# Altium schematic sheet TopSheet.SchDoc(TopSheet)
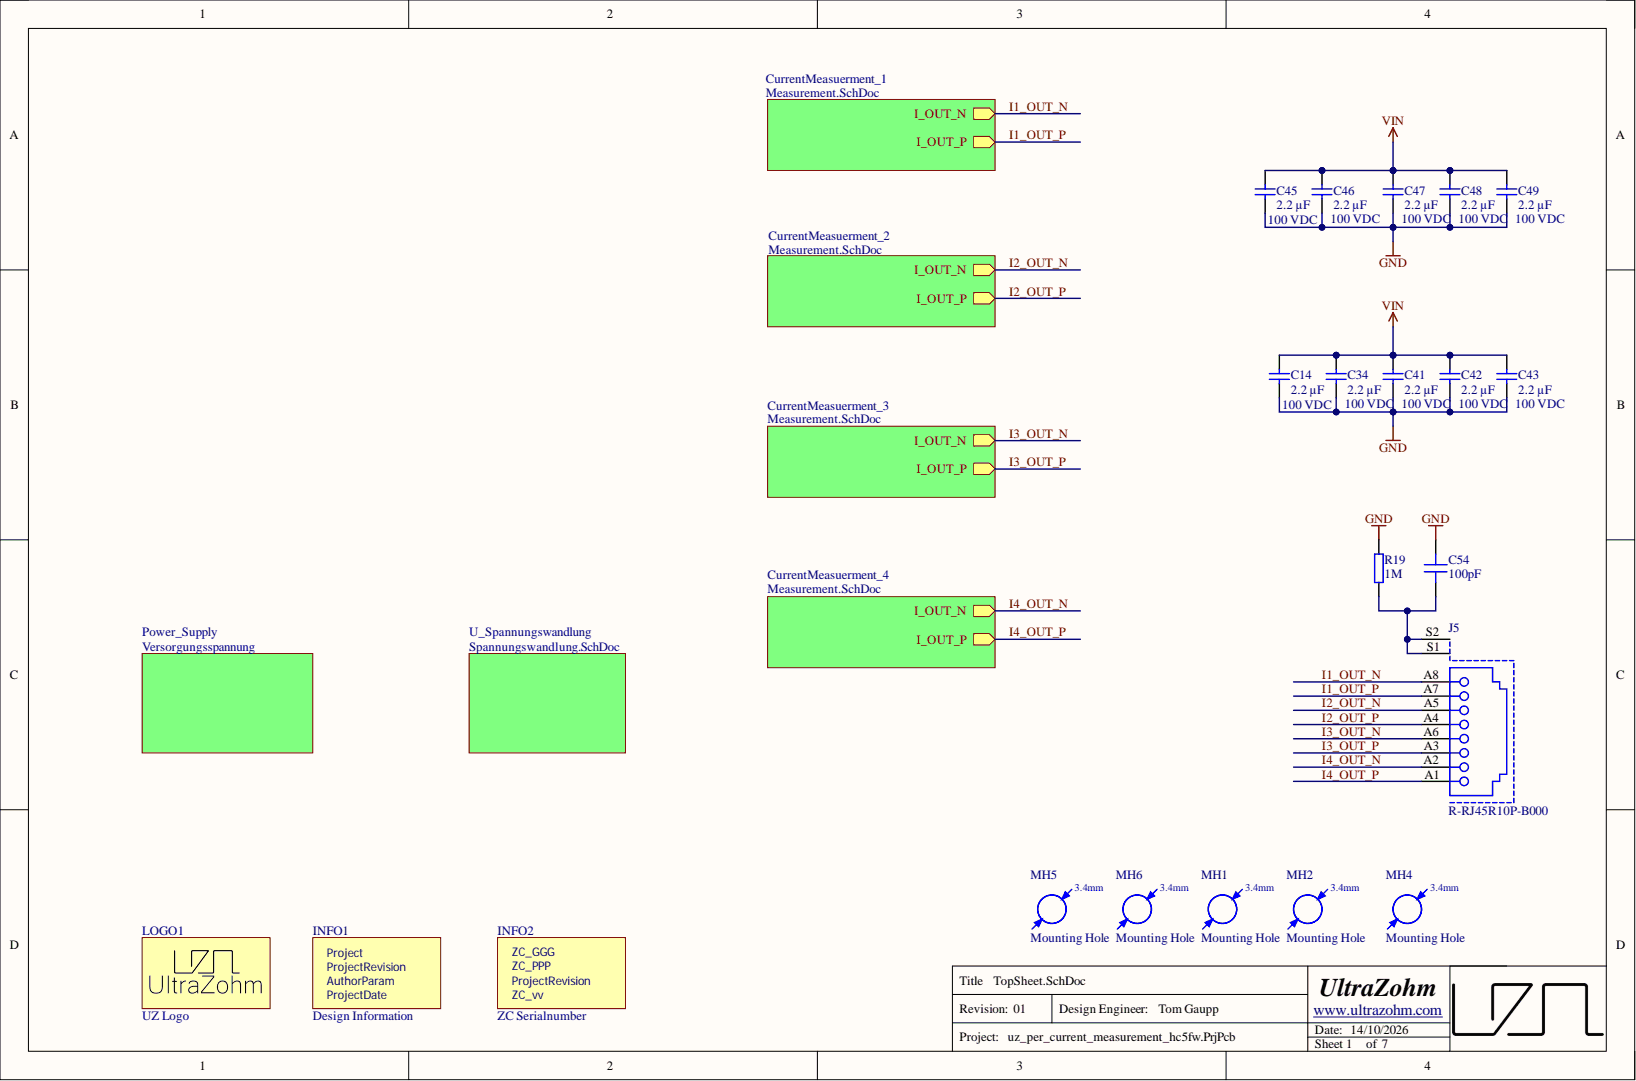
[diagram p0: TopSheet.SchDoc]

## Parts
C14  2.2 µF  [C_1210_TE]
C34  2.2 µF  Voltage Rating: 100 VDC  [C_1210_TE]
C41  2.2 µF  Voltage Rating: 100 VDC  [C_1210_TE]
C42  2.2 µF  Voltage Rating: 100 VDC  [C_1210_TE]
C43  2.2 µF  Voltage Rating: 100 VDC  [C_1210_TE]
C45  2.2 µF  [C_1210_TE]
C46  2.2 µF  Voltage Rating: 100 VDC  [C_1210_TE]
C47  2.2 µF  Voltage Rating: 100 VDC  [C_1210_TE]
C48  2.2 µF  Voltage Rating: 100 VDC  [C_1210_TE]
C49  2.2 µF  Voltage Rating: 100 VDC  [C_1210_TE]
C54  100pF  [C_1206_TE]
INFO1  Design Information  [Design_info]  (no BOM)
INFO2  ZC Serialnumber  [ZC-Serial]  (no BOM)
J5  R-RJ45R10P-B000  [R-RJ45R10P-B000]
LOGO1  UZ Logo  value Logo  Component Type: Logo  [UZ_Logo_small]  (no BOM)
MH1  Mounting Hole  [MH_3.4]  (no BOM)
MH2  Mounting Hole  [MH_3.4]  (no BOM)
MH4  Mounting Hole  [MH_3.4]  (no BOM)
MH5  Mounting Hole  [MH_3.4]  (no BOM)
MH6  Mounting Hole  [MH_3.4]  (no BOM)
R19  1M  [RES 0603_1608]

## Nets
GND: C14.2 C34.2 C41.2 C42.2 C43.2 C45.2 C46.2 C47.2 C48.2 C49.2 C54.2 R19.2
VIN: C14.1 C34.1 C41.1 C42.1 C43.1 C45.1 C46.1 C47.1 C48.1 C49.1
I1_OUT_N: J5.A8
I1_OUT_P: J5.A7
I2_OUT_N: J5.A5
I2_OUT_P: J5.A4
I3_OUT_N: J5.A6
I3_OUT_P: J5.A3
I4_OUT_N: J5.A2
I4_OUT_P: J5.A1
NetC54_1: C54.1 J5.S1 J5.S2 R19.1

## Library data of the parts
C14 C45 = FS32X225K101EGG: 100V 2.2uF X7R ±10% 1210 Multilayer Ceramic Capacitors MLCC - SMD/SMT ROHS; Manufacturer: PSA(Prosperity Dielectrics); Created from: AGeiger; Database Table Name: Capacitors - SMD; Voltage Rating: 100 VDC; Component Kind: Standard; Component Type: Capacitor 1210; ComponentLink1Description: Datasheet; ComponentLink1URL: https://www.lcsc.com/datasheet/lcsc_datasheet_2410121308_PSA-Prosperity-Dielectrics-FS32X225K101EGG_C153036.pdf; Created On: 11.03.2025 16:22:30; Device Package: 1210; Last Updated: 27.05.2025 19:11:48; LCSC Part Number: C153036; Library Name: user.DbLib; Live Cycle Status: 1; Manufacturer Part Number: FS32X225K101EGG; Mounting Type: SMD; Operating Temperature: -55 to +125°C; PartID: 170; Series: FS; Supplier 1: LCSC; Supplier Part Number 1: C153036; Tolerance: 10%; Type: Capacitor; Value: 2.2 µF
C34 C41-C43 C46-C49 = FS32X225K101EGG: 100V 2.2uF X7R ±10% 1210 Multilayer Ceramic Capacitors MLCC - SMD/SMT ROHS; Manufacturer: PSA(Prosperity Dielectrics); Created from: AGeiger; Database Table Name: Capacitors - SMD; Component Kind: Standard; Component Type: Capacitor 1210; ComponentLink1Description: Datasheet; ComponentLink1URL: https://www.lcsc.com/datasheet/lcsc_datasheet_2410121308_PSA-Prosperity-Dielectrics-FS32X225K101EGG_C153036.pdf; Created On: 11.03.2025 16:22:30; Device Package: 1210; Last Updated: 27.05.2025 19:11:48; LCSC Part Number: C153036; Library Name: user.DbLib; Live Cycle Status: 1; Manufacturer Part Number: FS32X225K101EGG; Mounting Type: SMD; Operating Temperature: -55 to +125°C; PartID: 170; Series: FS; Supplier 1: LCSC; Supplier Part Number 1: C153036; Tolerance: 10%; Type: Capacitor; Value: 2.2 µF
C54 = 1206CG101J102NT: 100pF 1kV C0G ±5% 1206 Multilayer Ceramic Capacitors MLCC - SMD/SMT ROHS; Manufacturer: FH (Guangdong Fenghua Advanced Tech); Created from: TGaupp; Database Table Name: Capacitors - SMD; Component Kind: Standard; Component Type: Capacitor 1206; ComponentLink1Description: Datasheet; ComponentLink1URL: https://jlcpcb.com/partdetail/9713-1206CG101J102NT/C9188; Created On: 07/10/2026 14:40:40; Device Package: 1206; Last Updated: 07/10/2026 14:40:40; LCSC Part Number: C9188; Library Name: user.DbLib; Live Cycle Status: 1; Manufacturer Part Number: 1206CG101J102NT; Mounting Type: SMD; Operating Temperature: -55 to +125°C; PartID: 514; Series: C0G; Stock number: not stored; Supplier 1: JLCPCB; Supplier Part Number 1: C9188; Tolerance: 5 %; Type: Capacitor; Value: 100pF; Voltage Rating: 1kV
INFO1 = Design Information: Manufacturer: UltraZohm; Created from: MGremer; Database Table Name: Misc; Component Type: Design Information; ComponentLink1Description: https://docs.ultrazohm.com/; ComponentLink1URL: homepage; Created On: 03/11/2021 09:04:23; Description: Design Information; Last Updated: 15/04/2025 09:29:36; Library Name: user.DbLib; Live Cycle Status: 1; Manufacturer Part Number: Design Information; PartID: 12; Supplier 1: -; Supplier Part Number 1: -; Type: Design Information; Value: Design Information
INFO2 = ZC-Serial: Manufacturer: UltraZohm; Created from: FRosner; Database Table Name: Misc; Value: GGG-PPP-VVvv; Component Type: ZC Serialnumber; ComponentLink1Description: https://docs.ultrazohm.com/; ComponentLink1URL: homepage; Created On: 15/04/2025 09:17:14; Description: ZC Serialnumber; Last Updated: 15/04/2025 09:17:14; Library Name: user.DbLib; Live Cycle Status: 1; Manufacturer Part Number: ZC-Serial; PartID: 58; Supplier 1: -; Supplier Part Number 1: -; Type: Serial Number
J5 = R-RJ45R10P-B000: RJ45Receptacle 1 Shielded Bend insert Without LED 0℃~+70℃ Plugin Ethernet Connectors / Modular Connectors (RJ45 RJ11) ROHS; Manufacturer: Ckmtw(Shenzhen Cankemeng); Created from: KIlhan; Component Kind: Standard; ComponentLink1Description: Datasheet; ComponentLink1URL: https://jlcpcb.com/api/file/downloadByFileSystemAccessId/8588892085580021760; Created On: 20/03/2025 09:19:07; Database Table Name: Connector; Last Updated: 20/03/2025 13:46:21; LCSC Part Number: C386758; Library Name: user.DbLib; Manufacturer Part Number: R-RJ45R10P-B000; Mounting Type: THT; Operating Temperature: 0℃~+70℃; PartID: 57; Series: RJ45; Supplier 1: LCSC; Supplier Part Number 1: C386758; Value: R-RJ45R10P-B000
LOGO1 = Logo small: Manufacturer: UltraZohm; Created from: MGremer; Database Table Name: Misc; ComponentLink1Description: https://docs.ultrazohm.com/; ComponentLink1URL: homepage; Created On: 03.11.2021 09:04:23; Description: UZ Logo; Last Updated: 03.11.2021 09:05:50; Library Name: user.DbLib; Live Cycle Status: 1; Manufacturer Part Number: Logo small; PartID: 10; Supplier 1: -; Supplier Part Number 1: -; Type: Logo; Created: Jan2021_Liegmann
MH1 MH2 MH4-MH6 = Mounting Hole: Created from: MHlatky; Database Table Name: Misc; Component Type: Mounting Hole; ComponentLink1Description: Datasheet; Created On: 26.01.2021 09:19:51; Description: Mounting Hole; Device Package: -; Last Updated: 21.03.2022 23:05:12; Library Name: user.DbLib; Live Cycle Status: 1; Manufacturer: Mounting Hole; Manufacturer Part Number: Mounting Hole; PartID: 16; Stock number: not stored; Supplier 1: Mounting Hole; Supplier Part Number 1: Mounting Hole; Type: Mounting Hole; Value: Mounting Hole
R19 = CRCW06031M00FKEAC: SMD resistor 1%; Manufacturer: Vishay / Dale; Created from: MGremer; Database Table Name: Resistors - SMD; Component Kind: Standard; Component Type: SMD resistor; ComponentLink1Description: Datasheet; ComponentLink1URL: https://www.mouser.de/datasheet/2/427/crcwce3-1762584.pdf; Created On: 14/12/2020 16:17:14; Device Package: 0603; Last Updated: 07/10/2026 14:27:53; LCSC Part Number: C844537; Library Name: user.DbLib; Live Cycle Status: 1; Manufacturer Part Number: CRCW06031M00FKEAC; Mounting Type: SMD; PartID: 179; Stock number: not stored; Supplier 1: Mouser; Supplier Part Number 1: 71-CRCW06031M00FKEAC; Tolerance: 1%; Type: Resistor; Value: 1M
